# Altium schematic sheet Receiver.SchDoc
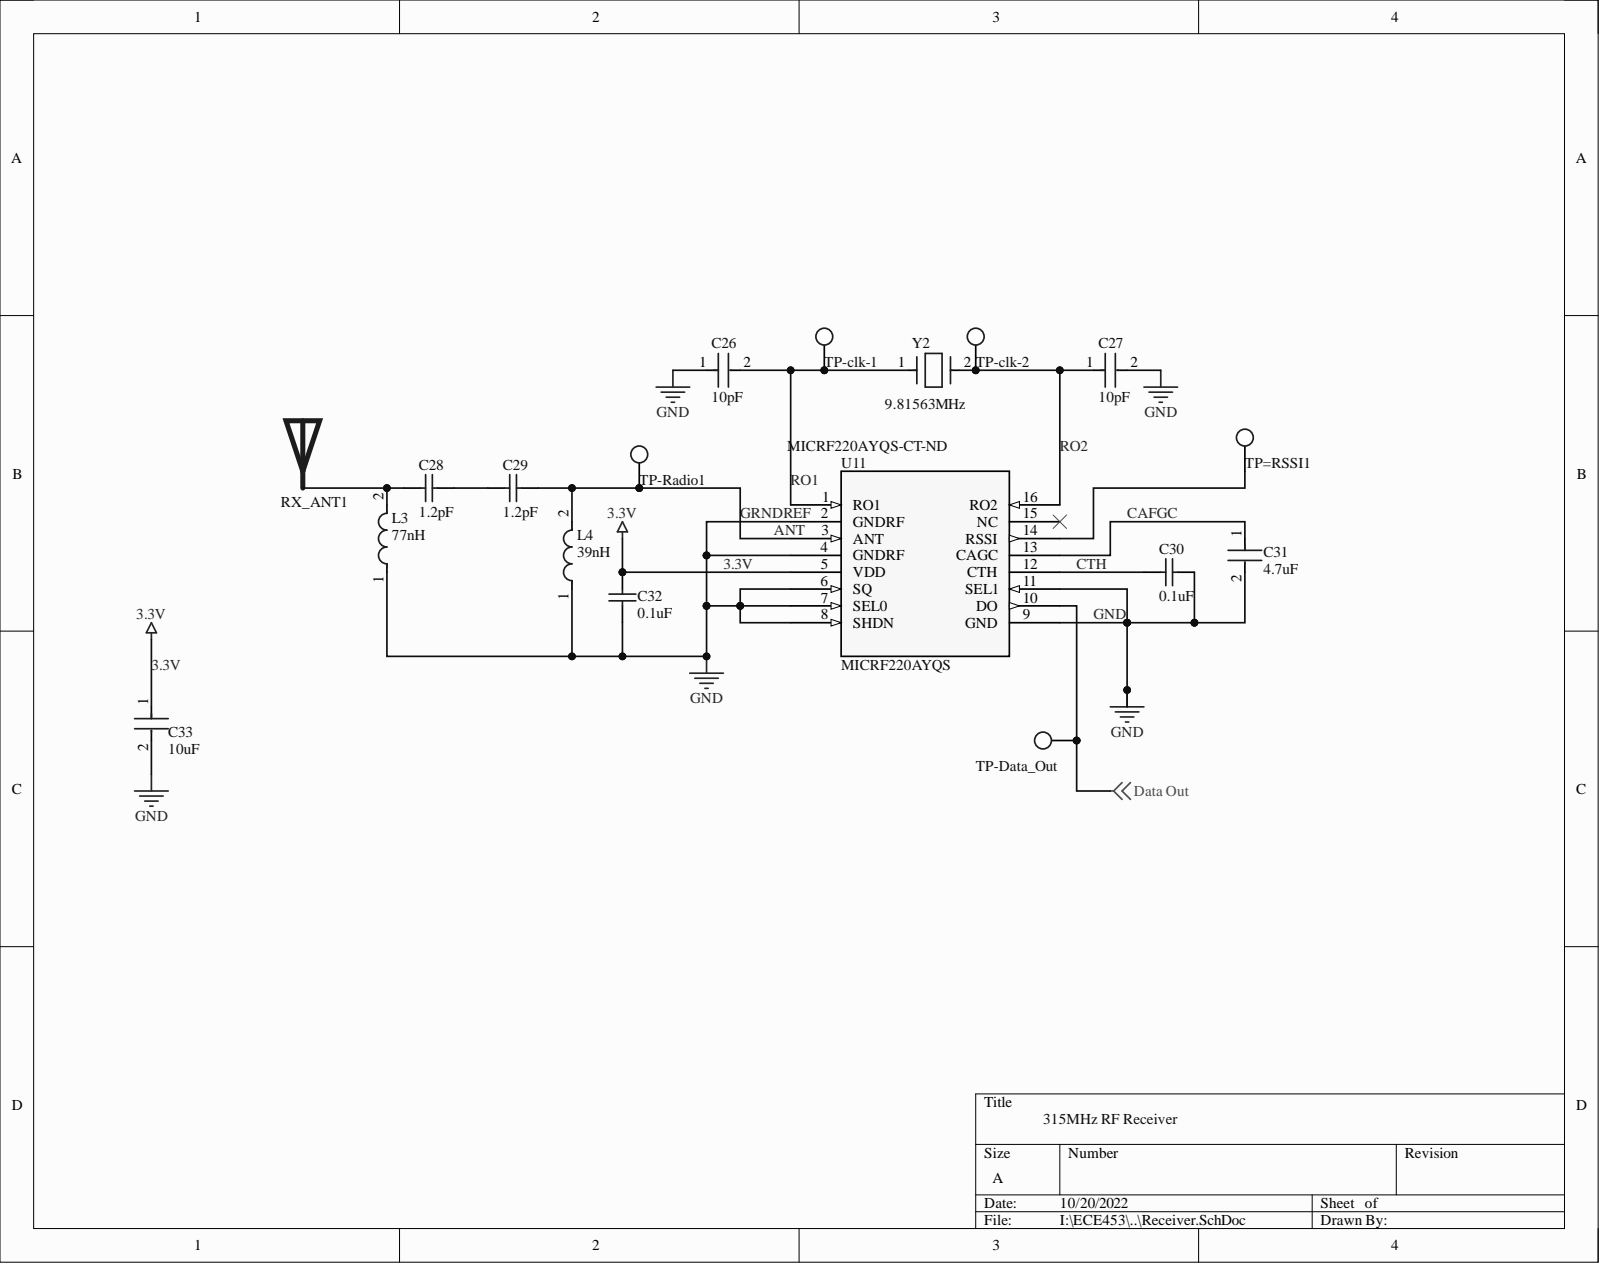
[diagram p0: Receiver.SchDoc]

## Parts
C26  10pF  [FP-C0603C-CF-MFG]
C27  10pF  [FP-C0603C-CF-MFG]
C28  1.2pF  [CAPC1005X55X25LL05T10]
C29  1.2pF  [CAPC1005X55X25LL05T10]
C30  0.1uF  [CAPC1005X56X25LL10T15]
C31  4.7uF  [FP-C1005-050-0_15-IPC_C]
C32  0.1uF  [CAPC1005X56X25LL10T15]
C33  10uF  [FP-C0805C-DG-MFG]
L3  77nH  [FP-LQW15CN_10-MFG]
L4  39nH  [FP-LQW15AN_80-MFG]
RX_ANT1  RX Antenna  [RX_Antenna]  (value not drawn)
TP-clk-1  TP_1206  [TP-SMC-1206]  (value not drawn)
TP-clk-2  TP_1206  [TP-SMC-1206]  (value not drawn)
TP-Data_Out  TP_1206  [TP-SMC-1206]  (value not drawn)
TP-Radio1  TP_1206  [TP-SMC-1206]  (value not drawn)
TP=RSSI1  TP_1206  [TP-SMC-1206]  (value not drawn)
U11  MICRF220AYQS  Supplier Part Number 1: MICRF220AYQS-CT-ND  [SOP63P600X175-16N]
Y2  9.81563MHz  [FP-ABM7-MFG]

## Nets
Data Out: TP-Data_Out.1 U11.10(DO)
3.3V: C32.1 C33.1 U11.5(VDD)
ANT: C26.1 C27.2 C29.2 C30.2 C31.2 C32.2 C33.2 L3.1 L4.1 L4.2 TP-Radio1.1 U11.2(GNDRF) U11.3(ANT) U11.4(GNDRF) U11.6(SQ) U11.7(SEL0) U11.8(SHDN) U11.9(GND) U11.11(SEL1)
CAFGC: C31.1 U11.13(CAGC)
CTH: C30.1 U11.12(CTH)
RO1: C26.2 TP-clk-1.1 U11.1(RO1) Y2.1
RO2: C27.1 TP-clk-2.1 U11.16(RO2) Y2.2
NetC28_1: C28.1 L3.2
NetC28_2: C28.2 C29.1
NetTP=RSSI1_1: TP=RSSI1.1 U11.14(RSSI)

Not connected: U11 15(NC)

## Library data of the parts
C26 C27 = CMP-1035-00555-3: CAP CER 10PF 50V NP0 0603; Manufacturer: KEMET; Manufacturer Part Number: C0603C100D5GACTU; Automotive Grade: Grade 1; Material: Ceramic; Temperature Characteristic: C0G; Temperature Coefficient: 30ppm/°C; Tolerance: 0.5 pF; Automotive: Yes; Capacitance: 10 pF; Category: Cap; Component Kind: Standard; ComponentLink2Description: Datasheet; ComponentLink2URL: https://api.kemet.com/component-edge/download/datasheet/C0603C100J5GACAUTO.pdf; Device Class L1: Passive Components; Device Class L2: Capacitors; Device Class L3: Ceramic Capacitors; Digikey Description: CAP CER 10PF 50V NP0 0603; Height: 0.87mm; Lead Free: Yes; Library Name: Altium Content Vault; Mouser Description: Multilayer Ceramic Capacitors MLCC - SMD/SMT 50volts 10pF C0G 0.5%; Octopart: https://octopart.com/c0603c100d5gactu-kemet-9143648; Package: C0603C-CF; RoHS: TRUE; Temperature: -55°C to +125°C; Temperature Range High: +125°C; Temperature Range Low: -55°C; Value: 10 pF; Voltage Rating: 50 V
C28 C29 = CMP-1034-00083-2: Manufacturer: Murata; Part Number: GRM1555C1H1R2WA01D; Component Kind: Standard; Library Name: Altium Content Vault
C30 C32 = CMP-2008-02743-1: Manufacturer: AVX Interconnect / Elco; Part Number: 04026D104KAT2A; Component Kind: Standard; Library Name: Altium Content Vault
C31 = CMP-08246-005457-1: CAP CER 4.7UF 6.3V X5R 0402; Dielectric Material: Ceramic; Dissipation Factor: 10%; Tolerance: 20%; Capacitance: 4.7uF; Case Code (Imperial): 0402; Case Code (Metric): 1005; Case/Package: 0402; Component Kind: Standard; Construction: Flat; Depth: 0.5mm; Dielectric: X5R; Height: 0.5mm; Lead Free: Lead Free; Length: 1mm; Library Name: Altium Content Vault; Max Operating Temperature: 85°C; Min Operating Temperature: -55°C; Mount: Surface Mount; Package Quantity: 1; Packaging: Tape and Reel; Radiation Hardening: No; REACH SVHC: No SVHC; Resistor Type: Ceramic; Series: C; Termination: SMD/SMT; Thickness: 0.026inch; Voltage: 6.3V; Voltage Rating: 6.3V; Voltage Rating (DC): 6.3V; Weight: 2.3E-05oz; Width: 0.02inch
C33 = CMP-2007-03243-2: CAP CER 10UF 10V X5R 0805; 3DS Part Number: 220-00001; Manufacturer: Kemet; Manufacturer Part Number: C0805C106K8PACTU; Material: Ceramic; Temperature Coefficient: 15%; Tolerance: 10%; Automotive: No; Capacitance: 10uF; Category: Cap; Component Kind: Standard; ComponentLink2Description: Datasheet; ComponentLink2URL: https://content.kemet.com/datasheets/KEM_C1006_X5R_SMD.pdf; Device Class L1: Passive Components; Device Class L2: Capacitors; Device Class L3: Ceramic Capacitors; Digikey Description: CAP CER 10UF 10V X5R 0805; Height: 1.4mm; Ipc Land Pattern Name: CAPC200125X125; Lead Free: Yes; Library Name: Altium Content Vault; Mouser Description: CAP CER 10UF 10V X5R 0805; Octopart: https://octopart.com/c0805c106k8pactu-kemet-98697; Package: C0805C-DG; RoHS: TRUE; Temperature: -55°C to +85°C; Temperature Characteristic: X5R; Temperature Range High: +85°C; Temperature Range Low: -55°C; Value: 10uF; Voltage: 10V; Voltage Rating: 10V
L3 = CMP-06042-006679-1: Wire Wound Ferrite RF Inductor For Automotive 77nH ±5% 1100mA 0.09Ω 0402 (1005); Current Rating: 1.1A; DC Resistance (DCR): 90mR; Self Resonant Frequency: 2GHz; Shielding: Unshielded; Test Frequency: 100MHz; Case Code (Imperial): 0402; Case Code (Metric): 1005; Case/Package: 0402; Component Kind: Standard; Core Material: Ferrite; Height - Seated (Max): 0.024inch; Inductance: 77nH; Length: 0.039inch; Library Name: Altium Content Vault; Max Operating Temperature: 125°C; Min Operating Temperature: -40°C; Mount: Surface Mount; Packaging: Tape and Reel; Ratings: AEC-Q200; Resistor Type: Wirewound; Tolerance: 5%; Width: 0.02inch
L4 = CMP-06042-001660-1: Wire Wound RF Inductor 39nH ±5% 530mA 0.456Ω 0402 (1005); Core Material: Non-Magnetic; DC Resistance (DCR): 456mR; Q Factor: 28; Self Resonant Frequency: 3.4GHz; Shielding: Unshielded; Test Frequency: 100MHz; Case Code (Imperial): 0402; Case Code (Metric): 1005; Case/Package: 0402; Component Kind: Standard; Current Rating: 530mA; Height: 0.5mm; Height - Seated (Max): 0.024inch; Inductance: 39nH; Length: 0.039inch; Library Name: Altium Content Vault; Max DC Current: 530mA; Max Operating Temperature: 125°C; Min Operating Temperature: -55°C; Mount: Surface Mount; Package Quantity: 1; Packaging: Tape and Reel; Resistor Type: Wirewound; Termination: SMD/SMT; Tolerance: 5%; Width: 0.024inch
TP-clk-1 TP-clk-2 TP-Data_Out TP-Radio1 TP=RSSI1 = TP_1206: Test Point SMD 1206; Manufacturer: TE Connectivity (Tyco Electronics); Manufacturer Part Number: 1625854-1; Power Rating: N/R; Resistance Value: 0 Ohm; Case Size: 1206; Component Kind: Standard; ComponentLink1Description: https://datasheet.ciiva.com/6439/eng-ds-1773266-a-6439169.pdf; ComponentLink1URL: https://datasheet.ciiva.com/6439/eng-ds-1773266-a-6439169.pdf; ComponentLink2Description: https://datasheet.ciiva.com/30136/1773266-a-30136341.pdf; ComponentLink2URL: https://datasheet.ciiva.com/30136/1773266-a-30136341.pdf; ComponentLink3Description: https://datasheet.ciiva.com/6439/eng-ds-1773266-a-6439080.pdf; ComponentLink3URL: https://datasheet.ciiva.com/6439/eng-ds-1773266-a-6439080.pdf; ComponentLink4Description: https://datasheet.ciiva.com/6439/eng-ds-1773266-a-6439081.pdf; ComponentLink4URL: https://datasheet.ciiva.com/6439/eng-ds-1773266-a-6439081.pdf; ComponentLink5Description: https://datasheet.ciiva.com/6439/eng-ds-1773266-a-6439083.pdf; ComponentLink5URL: https://datasheet.ciiva.com/6439/eng-ds-1773266-a-6439083.pdf; Dimension: 3.2 x 1.6 x 1.25mm; Family: RC; Library Name: Mechanical.SchLib; Mounting: Surface Mount; Operating Temperature: -55 to 125 C; Packaging: Tape and Reel; Part No: 1625854-1; Pricing 1: 1=0.28, 10=0.261, 25=0.224, 50=0.1902, 100=0.1828, 250=0.1641, 500=0.1567, 1000=0.1306 (USD); RoHS: Yes; Stock 1: 31937; Supplier: Digi-Key; Supplier Part Number: A106143CT-ND; Temperature Coefficient: N/R; Terminal Pitch: N/R; Termination Style: N/R; Tolerance: N/R
U11 = MICRF220AYQS: No Description Available; Mfr_Name: Microchip; Component Kind: Standard; Library Name: MICRF220AYQS.SchLib; Manufacturer_Part_Number: MICRF220AYQS; RefDes: RefDes; Supplier 1: Digi-Key; Type: DEV; Copyright: Copyright (C) 2022 Ultra Librarian. All rights reserved.
Y2 = CMP-27762-013605-1: Crystal 9.81563MHz ±30ppm 10pF SMD-2 6mm x 3.5mm; ESR (Equivalent Series Resistance): 50R; Frequency Stability: 100ppm; Case/Package: SMD/SMT; Component Kind: Standard; Frequency: 9.81563MHz; Frequency Tolerance: 30ppm; Height: 0.055inch; Length: 0.236inch; Library Name: Altium Content Vault; Load Capacitance: 10pF; Max Operating Temperature: 85°C; Min Operating Temperature: -40°C; Mount: Surface Mount; Packaging: Tape and Reel; Width: 0.138inch
RX_ANT1 = RX Antenna: Component Kind: Standard; Library Name: team6.SCHLIB
